# Altium schematic sheet DOAN1.SchDoc
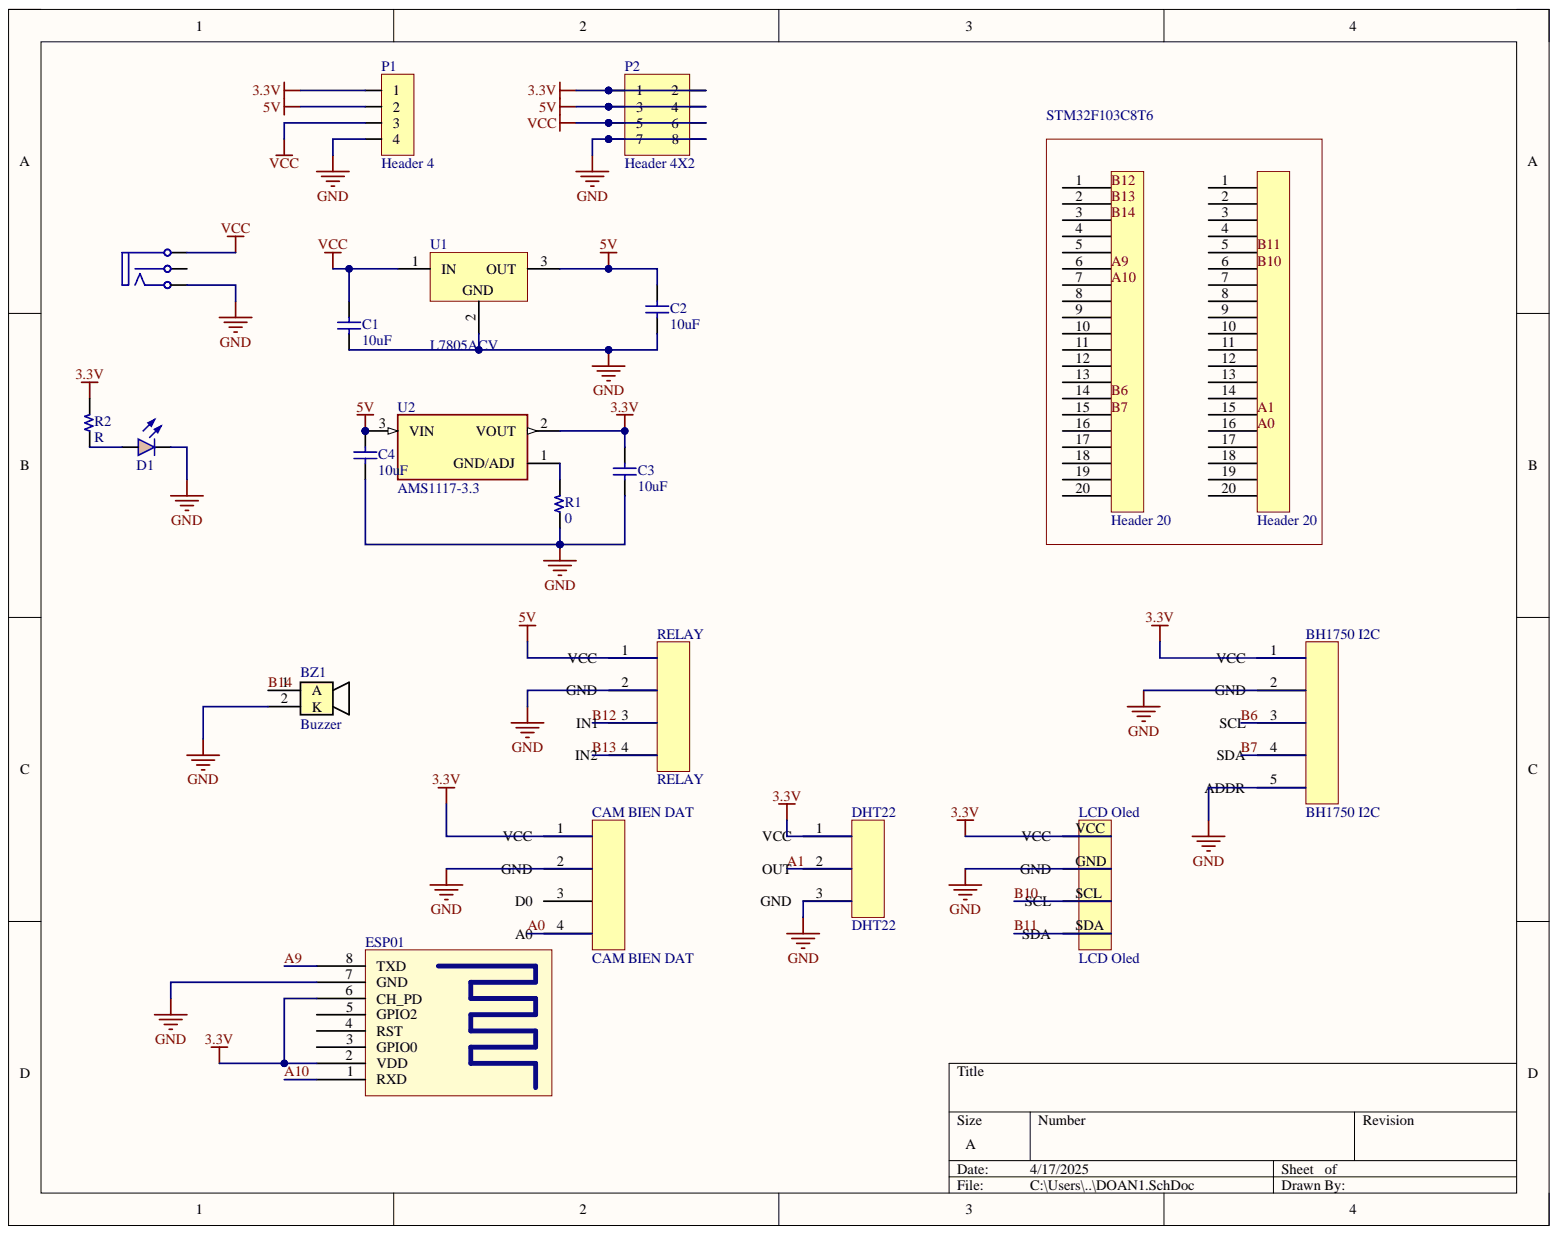
[diagram p0: DOAN1.SchDoc]

## Parts
BH1750_I2C  BH1750 I2C  [HDR/Header/5]
BZ1  Buzzer  [BUZZER]
C1  10uF  [CAP Ceramic/W200]
C2  10uF  [CAP Ceramic/W200]
C3  10uF  [CAP Ceramic/W200]
C4  10uF  [CAP Ceramic/W200]
CAM_BIEN_DAT  CAM BIEN DAT  [HDR/Header/4]
CON1  CON DC PWR  [CONN/DC/PWR/5.0MM]  (value not drawn)
D1  LED RED  [LED 10MM - RED]  (value not drawn)
DHT22  DHT22  [HDR/Header/3]
ESP01  Component_1  [ESP8266 ESP-01]  (value not drawn)
LCD_Oled  LCD Oled  [HDR/Header/4]
P1  Header 4  [HDR/Header/4]
P2  Header 4X2  [HDR/Header/8/DL]
R1  0  [R 1/4W/300]
R2  R  [R 1/4W/300]
RELAY  RELAY  [HDR/Header/4]
U1  L7805ACV  [PCBComponent_1 - duplicate]
U2  AMS1117-3.3  [SOT229P700X180-4N]
  Header 20  [HDR/Header/20]
  Header 20  [HDR/Header/20]

## Nets
3.3V: BH1750_I2C.1 C3.1 CAM_BIEN_DAT.1 DHT22.1 ESP01.2(VDD) ESP01.6(CH_PD) LCD_Oled.VCC P1.1 P2.1 P2.2 R2.2 U2.2
5V: C2.1 C4.1 P1.2 P2.3 P2.4 RELAY.1 U1.3 U2.3
GND: BH1750_I2C.2 BH1750_I2C.5 BZ1.2 C1.2 C2.2 C3.2 C4.2 CAM_BIEN_DAT.2 CON1.2 D1.2 DHT22.3 ESP01.7(GND) LCD_Oled.GND P1.4 P2.7 P2.8 R1.1 RELAY.2 U1.2
Net_1: .1
Net_2: .2
Net_3: .3
Net_4: .4
Net_5: .5
Net_7: .7
Net_8: .8
Net_9: .9
Net_10: .10
Net_11: .11
Net_12: .12
Net_13: .13
Net_14: .14
Net_16: .16
Net_17: .17
Net_18: .18
Net_19: .19
Net_20: .20
VCC: C1.1 CON1.3 P1.3 P2.5 P2.6 U1.1
A0: CAM_BIEN_DAT.4 .16
A1: DHT22.2 .15
A9: ESP01.8(TXD) .6
A10: ESP01.1(RXD) .7
B6: BH1750_I2C.3 .14
B7: BH1750_I2C.4 .15
B10: LCD_Oled.SCL .6
B11: LCD_Oled.SDA .5
B12: RELAY.3 .1
B13: RELAY.4 .2
B14: BZ1.1 .3
NetD1_1: D1.1 R2.1
NetR1_2: R1.2 U2.1

Not connected: CAM_BIEN_DAT 3; CON1 1; ESP01 3(GPIO0) 4(RST) 5(GPIO2)

## Library data of the parts
C1-C4 = Capacitor NP: Capacitor non-pol; Component Kind: Standard; Library Name: chipfc_libs.SchLib
CON1 = CON DC PWR: DC input connector; Component Kind: Standard; Library Name: connectors.SchLib
D1 = LED RED: Typical LED 3-pin 2-color; Component Kind: Standard; Library Name: led.SchLib
P1 = Header 4: Header, 4-Pin; Component Kind: Standard; Library Name: connectors.SchLib
P2 = Header 4X2: Header, 4-Pin, Dual row; Component Kind: Standard; Library Name: connectors.SchLib
R1 R2 = Resistor: Component Kind: Standard; Description: Resistor; Library Name: chipfc_libs.SchLib
U1 = CMP-0244-00484-1: Positive Voltage Regulator, 5V, 3-Pin TO-220; Component Kind: Standard; ComponentLink1Description: Manufacturer URL; ComponentLink1URL: http://www.st.com; ComponentLink2Description: Datasheet; ComponentLink2URL: http://www.st.com/internet/com/TECHNICAL_RESOURCES/TECHNICAL_LITERATURE/DATASHEET/CD00000444.pdf; ComponentLink3Description: Package Specification; ComponentLink3URL: http://www.st.com/internet/com/TECHNICAL_RESOURCES/TECHNICAL_LITERATURE/PACKAGE_INFORMATION/CD00004649.pdf; DatasheetVersion: 16/11/2011; Library Name: Components - 05.03.25 - 21.07.SchLib; PackageDescription: TO, Thru-Hole, Vertical, Heatsink Mounted; 3 In-Line Leads; Pitch 2.54 mm; PackageReference: TO220; PackageVersion: ver3.0
U2 = AMS1117-3.3: Sot223/Pkg 1-Amp 3.3-Volt Low Drop Out Voltage Regulatator; MF: Advanced Monolithic Systems; Availability: Not in stock; Check_prices: https://www.snapeda.com/parts/AMS1117-3.3/Advanced+Monolithic+Systems/view-part/?ref=eda; Component Kind: Standard; Library Name: AMS1117-3.3.IntLib; MP: AMS1117-3.3; Package: SOT-223 Seeed Technology; Price: None; SnapEDA_Link: https://www.snapeda.com/parts/AMS1117-3.3/Advanced+Monolithic+Systems/view-part/?ref=snap
BH1750_I2C = BH1750 I2C: Component Kind: Standard; Library Name: CAC LINH KIEN.SchLib
BZ1 = Buzzer: Component Kind: Standard; Library Name: chipfc_libs.SchLib
CAM_BIEN_DAT = CAM BIEN DAT: Component Kind: Standard; Library Name: CAC LINH KIEN.SchLib
DHT22 = DHT22: Component Kind: Standard; Library Name: CAC LINH KIEN.SchLib
ESP01 = Component_1: Component Kind: Standard; Library Name: ESPlam lai.SchLib
LCD_Oled = LCD Oled: Component Kind: Standard; Library Name: CAC LINH KIEN.SchLib
RELAY = RELAY: Component Kind: Standard; Library Name: CAC LINH KIEN.SchLib
  = Header 20: Component Kind: Standard; Library Name: Header20.SchLib
